# Altium schematic sheet ADC.SchDoc
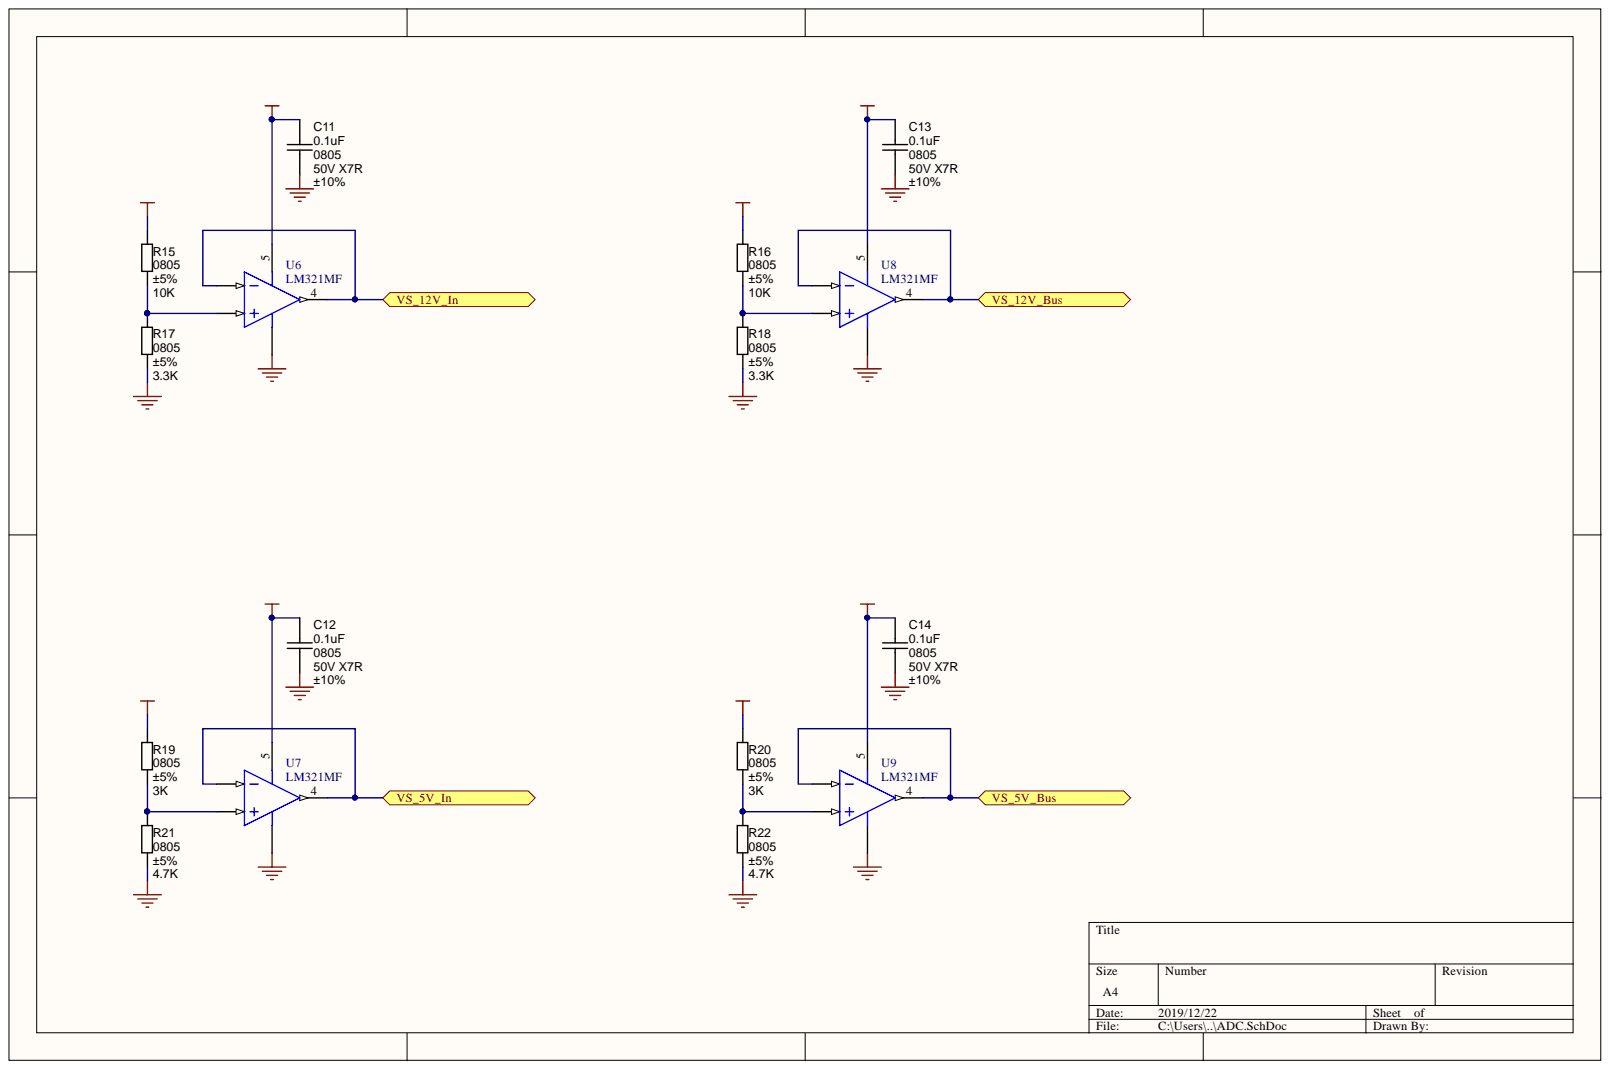
[diagram p0: ADC.SchDoc]

## Parts
C11  value 0.1uF  Package / Case: 0805  Tolerance: ±10%  [C0805-EIA-LevelB]  (hidden comment: approved sub OK)
C12  value 0.1uF  Package / Case: 0805  Tolerance: ±10%  [C0805-EIA-LevelB]  (hidden comment: approved sub OK)
C13  value 0.1uF  Package / Case: 0805  Tolerance: ±10%  [C0805-EIA-LevelB]  (hidden comment: approved sub OK)
C14  value 0.1uF  Package / Case: 0805  Tolerance: ±10%  [C0805-EIA-LevelB]  (hidden comment: approved sub OK)
R15  value 10K  Package / Case: 0805  Tolerance: ±5%  [RCS0805-e3]  (hidden comment: Thick Film)
R16  value 10K  Package / Case: 0805  Tolerance: ±5%  [RCS0805-e3]  (hidden comment: Thick Film)
R17  value 3.3K  Package / Case: 0805  Tolerance: ±5%  [RCS0805-e3]  (hidden comment: RES_3.3K_0805_5%)
R18  value 3.3K  Package / Case: 0805  Tolerance: ±5%  [RCS0805-e3]  (hidden comment: RES_3.3K_0805_5%)
R19  value 3K  Package / Case: 0805  Tolerance: ±5%  [RCS0805-e3]  (hidden comment: RES_3K_0805_5%)
R20  value 3K  Package / Case: 0805  Tolerance: ±5%  [RCS0805-e3]  (hidden comment: RES_3K_0805_5%)
R21  value 4.7K  Package / Case: 0805  Tolerance: ±5%  [RCS0805-e3]  (hidden comment: RES_4.7K_0805_5%)
R22  value 4.7K  Package / Case: 0805  Tolerance: ±5%  [RCS0805-e3]  (hidden comment: RES_4.7K_0805_5%)
U6  LM321MF  [MF05A_N]
U7  LM321MF  [MF05A_N]
U8  LM321MF  [MF05A_N]
U9  LM321MF  [MF05A_N]

## Ports
VS_5V_Bus, VS_5V_In, VS_12V_Bus, VS_12V_In

## Nets
5VBUS: R20.1
5Vin: R19.1
12VBUS: R16.1
12Vin: R15.1
GND: C11.2 C12.2 C13.2 C14.2 R17.2 R18.2 R21.2 R22.2 U6.2 U7.2 U8.2 U9.2
P3V3: C11.1 C12.1 C13.1 C14.1 U6.5 U7.5 U8.5 U9.5
VS_5V_Bus: U9.3 U9.4 ; port VS_5V_Bus
VS_5V_In: U7.3 U7.4 ; port VS_5V_In
VS_12V_Bus: U8.3 U8.4 ; port VS_12V_Bus
VS_12V_In: U6.3 U6.4 ; port VS_12V_In
NetR15_2: R15.2 R17.1 U6.1
NetR16_2: R16.2 R18.1 U8.1
NetR19_2: R19.2 R21.1 U7.1
NetR20_2: R20.2 R22.1 U9.1

## Library data of the parts
C11-C14 = CAPC_0.1uF_50V_X7R_0805_10%: Capacitor SMD Cer 0.1uF 50V X7R 0805 10% 100nF; Manufacturer 1: Murata Electronics; Manufacturer Part Number 1: GCD21BR71H104KA01L; Ratings: 50V X7R; SCDType: undef; Component Kind: Standard; Operating Temperature: -55°C ~ 125°C; Library Name: roger225_Altium_Library.IntLib
R15 R16 = RES_10K_0805_5%: Resistor SMD chip 10k Ohm 0.125W 5% 0805; Manufacturer 1: Vishay/Dale; Manufacturer Part Number 1: CRCW080510K0JNEA; Ratings: 1/8W; SCDType: spec; Component Kind: Standard; Library Name: roger225_Altium_Library.IntLib
R17 R18 = RES_3.3K_0805_5%: Resistor SMD chip 3.3k Ohm 0.125W 5% 0805; Manufacturer 1: Vishay/Dale; Manufacturer Part Number 1: CRCW08053K30JNEA; Ratings: 1/8W; SCDType: spec; Component Kind: Standard; Library Name: roger225_Altium_Library.IntLib
R19 R20 = RES_3K_0805_5%: Resistor SMD chip 3k Ohm 0.125W 5% 0805; Manufacturer 1: Vishay/Dale; Manufacturer Part Number 1: CRCW08053K00FKEAC; Ratings: 1/8W; SCDType: spec; Component Kind: Standard; Library Name: roger225_Altium_Library.IntLib
R21 R22 = RES_4.7K_0805_5%: Resistor SMD chip 4.7k Ohm 0.125W 5% 0805; Manufacturer 1: Vishay/Dale; Manufacturer Part Number 1: CRCW08054K70JNEA; Ratings: 1/8W; SCDType: spec; Component Kind: Standard; Library Name: roger225_Altium_Library.IntLib
U6-U9 = LM321MF: Low Power Single Op Amp; Manufacturer: National Semiconductor; CompType: Amplifiers; PackageTopMarking: A63A*XTT; PowerWise: N; Code_IPC: SOT23-5; Component Kind: Standard; ComponentLink1Description: LM321 Datasheet; ComponentLink1URL: http://www.national.com/ds/LM/LM321.pdf; ComponentLink2Description: LM321 Web Page; ComponentLink2URL: http://www.national.com/pf/LM/LM321.html; ComponentLink3Description: MF05A Package Page; ComponentLink3URL: http://www.national.com/packaging/folders/mf05a.html; DatasheetVersion: Apr-2001; DigiKeyPartNumber: LM321MFCT-ND; LatestRevisionDate: 13-Jan-2009; LatestRevisionNote: Sim Model Added.; Library Name: NSC Amplifier.IntLib; PackageDescription: Molded SOT-23, .115 x .063 x .040 in Body, 5 Lead, .0375 in Pitch; PackageReference: MF05A; PackageVersion: revD, Aug-2008; PartNumber: LM321MF; PinCount: 5; Published: 4-Dec-2008; RoHS: O; Simulation: LM324; Publisher: Altium Limited
